# Altium schematic sheet 08_ATK-DLMP257_NET1.SchDoc
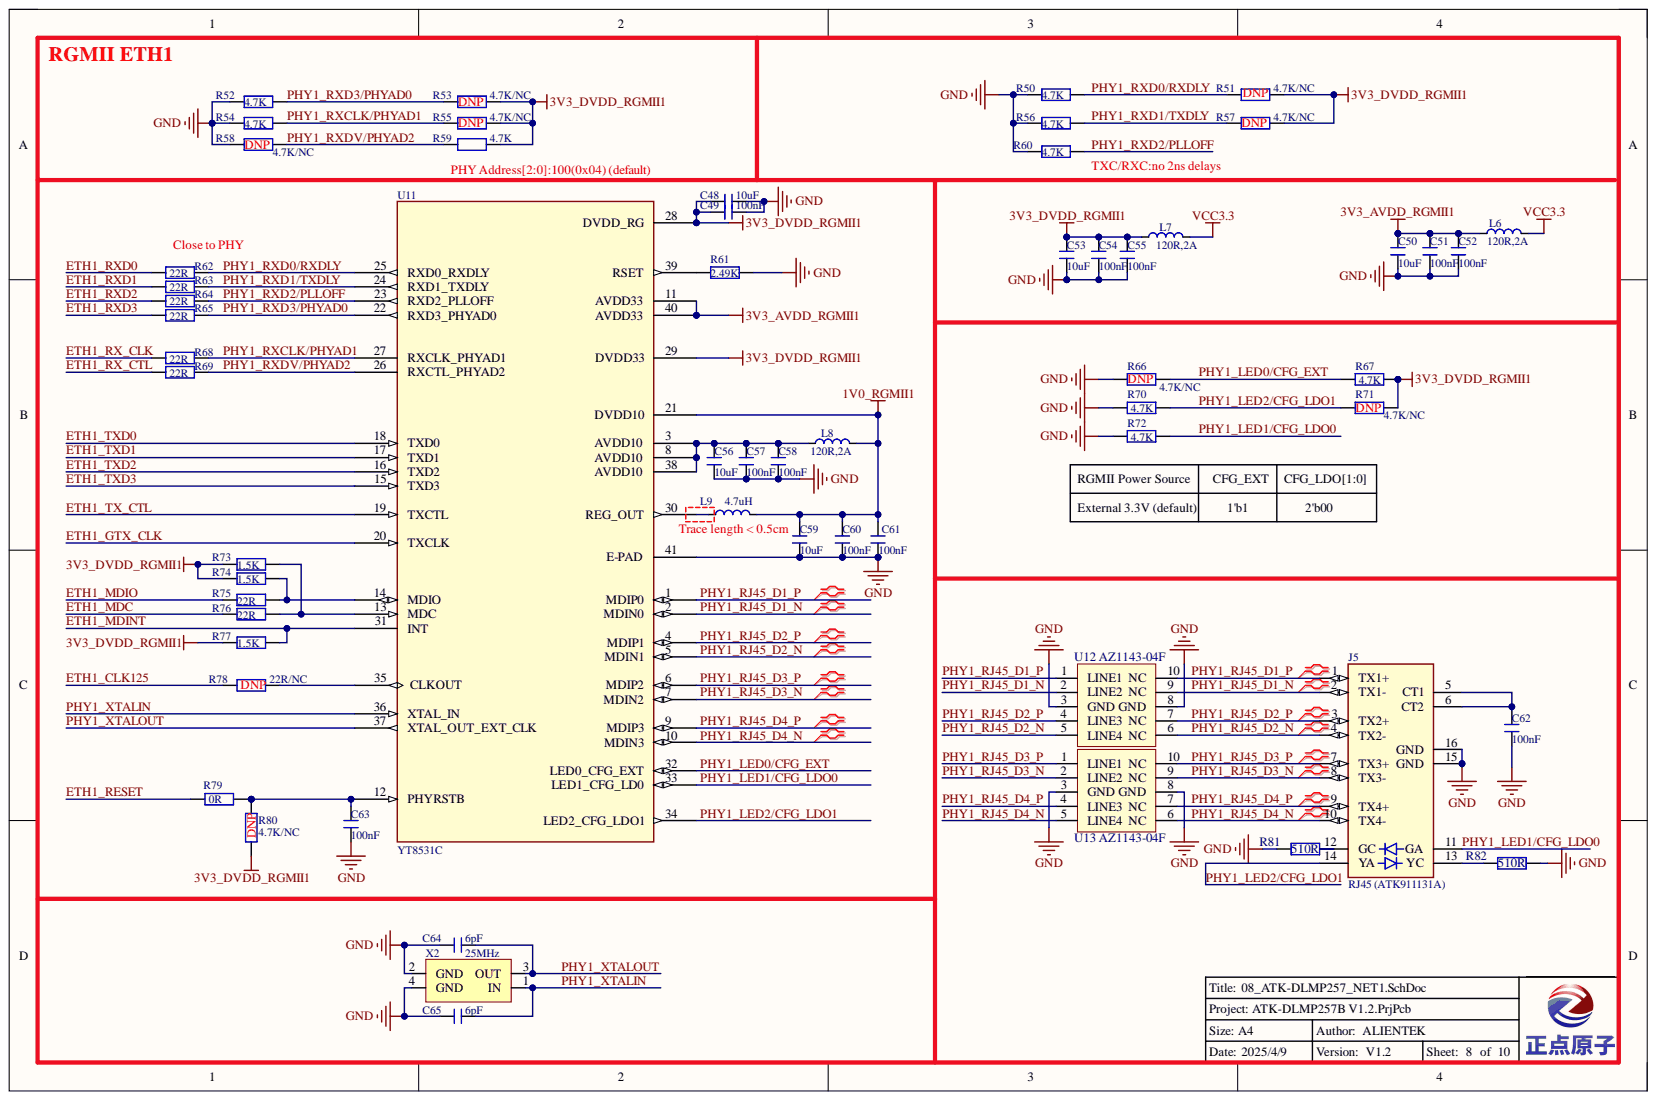
[diagram p0: 08_ATK-DLMP257_NET1.SchDoc]

## Parts
C48  10uF  [C 0603]
C49  100nF  [C 0402]
C50  10uF  [C 0603]
C51  100nF  [C 0402]
C52  100nF  [C 0402]
C53  10uF  [C 0603]
C54  100nF  [C 0402]
C55  100nF  [C 0402]
C56  10uF  [C 0603]
C57  100nF  [C 0402]
C58  100nF  [C 0402]
C59  10uF  [C 0603]
C60  100nF  [C 0402]
C61  100nF  [C 0402]
C62  100nF  [C 0603]
C63  100nF  [C 0402]
C64  6pF  [C 0402]
C65  6pF  [C 0402]
J5  RJ45 (ATK911131A)  [HR911131A]
L6  120R,2A  [L 0603]
L7  120R,2A  [L 0603]
L8  120R,2A  [L 0603]
L9  4.7uH  [L_2520]
R50  4.7K  [R 0402]
R51  4.7K/NC  [R 0402]
R52  4.7K  [R 0402]
R53  4.7K/NC  [R 0402]
R54  4.7K  [R 0402]
R55  4.7K/NC  [R 0402]
R56  4.7K  [R 0402]
R57  4.7K/NC  [R 0402]
R58  4.7K/NC  [R 0402]
R59  4.7K  [R 0402]
R60  4.7K  [R 0402]
R61  2.49K  [R 0402]
R62  22R  [R 0402]
R63  22R  [R 0402]
R64  22R  [R 0402]
R65  22R  [R 0402]
R66  4.7K/NC  [R 0402]
R67  4.7K  [R 0402]
R68  22R  [R 0402]
R69  22R  [R 0402]
R70  4.7K  [R 0603]
R71  4.7K/NC  [R 0603]
R72  4.7K  [R 0603]
R73  1.5K  [R 0402]
R74  1.5K  [R 0402]
R75  22R  [R 0402]
R76  22R  [R 0402]
R77  1.5K  [R 0402]
R78  22R/NC  [R 0402]
R79  0R  [R 0402]
R80  4.7K/NC  [R 0402]
R81  510R  [R 0603]
R82  510R  [R 0603]
U11  YT8531C  [QFN40]
U12  AZ1143-04F  [DFN2510P10E]
U13  AZ1143-04F  [DFN2510P10E]
X2  25MHz  [XTAL-3225]

## Nets
1V0_RGMII1: C59.2 C60.2 C61.2 L8.2 L9.2 U11.21(DVDD10)
3V3_AVDD_RGMII1: C50.2 C51.2 C52.2 L6.1 U11.11(AVDD33) U11.40(AVDD33)
3V3_DVDD_RGMII1: C48.2 C49.2 C53.2 C54.2 C55.2 L7.1 R51.2 R53.2 R55.2 R57.2 R59.2 R67.2 R71.2 R73.1 R74.1 R77.1 R80.2 U11.28(DVDD_RG) U11.29(DVDD33)
GND: C48.1 C49.1 C50.1 C51.1 C52.1 C53.1 C54.1 C55.1 C56.1 C57.1 C58.1 C59.1 C60.1 C61.1 C62.1 C63.1 C64.2 C65.2 J5.15(GND) J5.16(GND) R50.1 R52.2 R54.2 R56.1 R58.2 R60.1 R61.2 R66.1 R70.1 R72.1 R81.1 R82.2 U11.41(E-PAD) U12.3(GND) U12.8(GND) U13.3(GND) U13.8(GND) X2.2(GND) X2.4(GND)
VCC3.3: L6.2 L7.2
ETH1_CLK125: R78.1
ETH1_GTX_CLK: U11.20(TXCLK)
ETH1_MDC: R76.1
ETH1_MDINT: R77.2 U11.31(INT)
ETH1_MDIO: R75.1
ETH1_RESET: R79.1
ETH1_RX_CLK: R68.2
ETH1_RX_CTL: R69.2
ETH1_RXD0: R62.2
ETH1_RXD1: R63.2
ETH1_RXD2: R64.2
ETH1_RXD3: R65.2
ETH1_TX_CTL: U11.19(TXCTL)
ETH1_TXD0: U11.18(TXD0)
ETH1_TXD1: U11.17(TXD1)
ETH1_TXD2: U11.16(TXD2)
ETH1_TXD3: U11.15(TXD3)
PHY1_LED0/CFG_EXT: R66.2 R67.1 U11.32(LED0_CFG_EXT)
PHY1_LED1/CFG_LDO0: J5.11(GA) R72.2 U11.33(LED1_CFG_LD0)
PHY1_LED2/CFG_LDO1: J5.14(YA) R70.2 R71.1 U11.34(LED2_CFG_LDO1)
PHY1_RJ45_D1_N: J5.2(TX1-) U11.2(MDIN0) U12.2(LINE2) U12.9(NC)
PHY1_RJ45_D1_P: J5.1(TX1+) U11.1(MDIP0) U12.1(LINE1) U12.10(NC)
PHY1_RJ45_D2_N: J5.4(TX2-) U11.5(MDIN1) U12.5(LINE4) U12.6(NC)
PHY1_RJ45_D2_P: J5.3(TX2+) U11.4(MDIP1) U12.4(LINE3) U12.7(NC)
PHY1_RJ45_D3_N: J5.8(TX3-) U11.7(MDIN2) U13.2(LINE2) U13.9(NC)
PHY1_RJ45_D3_P: J5.7(TX3+) U11.6(MDIP2) U13.1(LINE1) U13.10(NC)
PHY1_RJ45_D4_N: J5.10(TX4-) U11.10(MDIN3) U13.5(LINE4) U13.6(NC)
PHY1_RJ45_D4_P: J5.9(TX4+) U11.9(MDIP3) U13.4(LINE3) U13.7(NC)
PHY1_RXCLK/PHYAD1: R54.1 R55.1 R68.1 U11.27(RXCLK_PHYAD1)
PHY1_RXD0/RXDLY: R50.2 R51.1 R62.1 U11.25(RXD0_RXDLY)
PHY1_RXD1/TXDLY: R56.2 R57.1 R63.1 U11.24(RXD1_TXDLY)
PHY1_RXD2/PLLOFF: R60.2 R64.1 U11.23(RXD2_PLLOFF)
PHY1_RXD3/PHYAD0: R52.1 R53.1 R65.1 U11.22(RXD3_PHYAD0)
PHY1_RXDV/PHYAD2: R58.1 R59.1 R69.1 U11.26(RXCTL_PHYAD2)
PHY1_XTALIN: C65.1 U11.36(XTAL_IN) X2.1(IN)
PHY1_XTALOUT: C64.1 U11.37(XTAL_OUT_EXT_CLK) X2.3(OUT)
NetC56_2: C56.2 C57.2 C58.2 L8.1 U11.3(AVDD10) U11.8(AVDD10) U11.38(AVDD10)
NetC62_2: C62.2 J5.5(CT1) J5.6(CT2)
NetC63_2: C63.2 R79.2 R80.1 U11.12(PHYRSTB)
NetJ5_12: J5.12(GC) R81.2
NetJ5_13: J5.13(YC) R82.1
NetL9_1: L9.1 U11.30(REG_OUT)
NetR61_1: R61.1 U11.39(RSET)
NetR73_2: R73.2 R76.2 U11.13(MDC)
NetR74_2: R74.2 R75.2 U11.14(MDIO)
NetR78_2: R78.2 U11.35(CLKOUT)

## Library data of the parts
C48-C65 = C: 无极性贴片电容; 版权归属: 源创客; BOM名称: 无极性贴片电容; Component Kind: Standard; 学习资源: www.ooocke.com; Library Name: Lycreturn.IntLib
J5 = HR911131A/C: 千兆以太网接口; Component Kind: Standard; Library Name: 接口类.SchLib
L6-L9 = L: 小功率贴片电感磁珠; 版权归属: 源创客; BOM名称: 小功率贴片电感; Component Kind: Standard; Library Name: 电阻-电容-电感.SchLib; 学习资源: www.ooocke.com
R50-R80 = R: 贴片电阻; 版权归属: 源创客; BOM名称: 贴片电阻; Component Kind: Standard; 学习资源: www.ooocke.com; Library Name: Lycreturn.IntLib
R81 R82 = R: 贴片电阻; 版权归属: 正点原子; BOM名称: 贴片电阻; Component Kind: Standard; 学习资源: www.openedv.com; Library Name: Lycreturn.IntLib
U11 = RTL8211F-CG: Component Kind: Standard; Library Name: ZZK_COMPONENT.IntLib
U12 U13 = AZ1143-04F: ESD保护二极管阵列; Component Kind: Standard; Library Name: 二极管-整流桥.SchLib
X2 = XTAL-4P: 4脚无源晶振; BOM名称: 4脚晶振; 版权归属: 源创客; Component Kind: Standard; 学习资源: www.ooocke.com; Library Name: Lycreturn.IntLib
